# Altium schematic sheet CompareVoltage.SchDoc(CV)
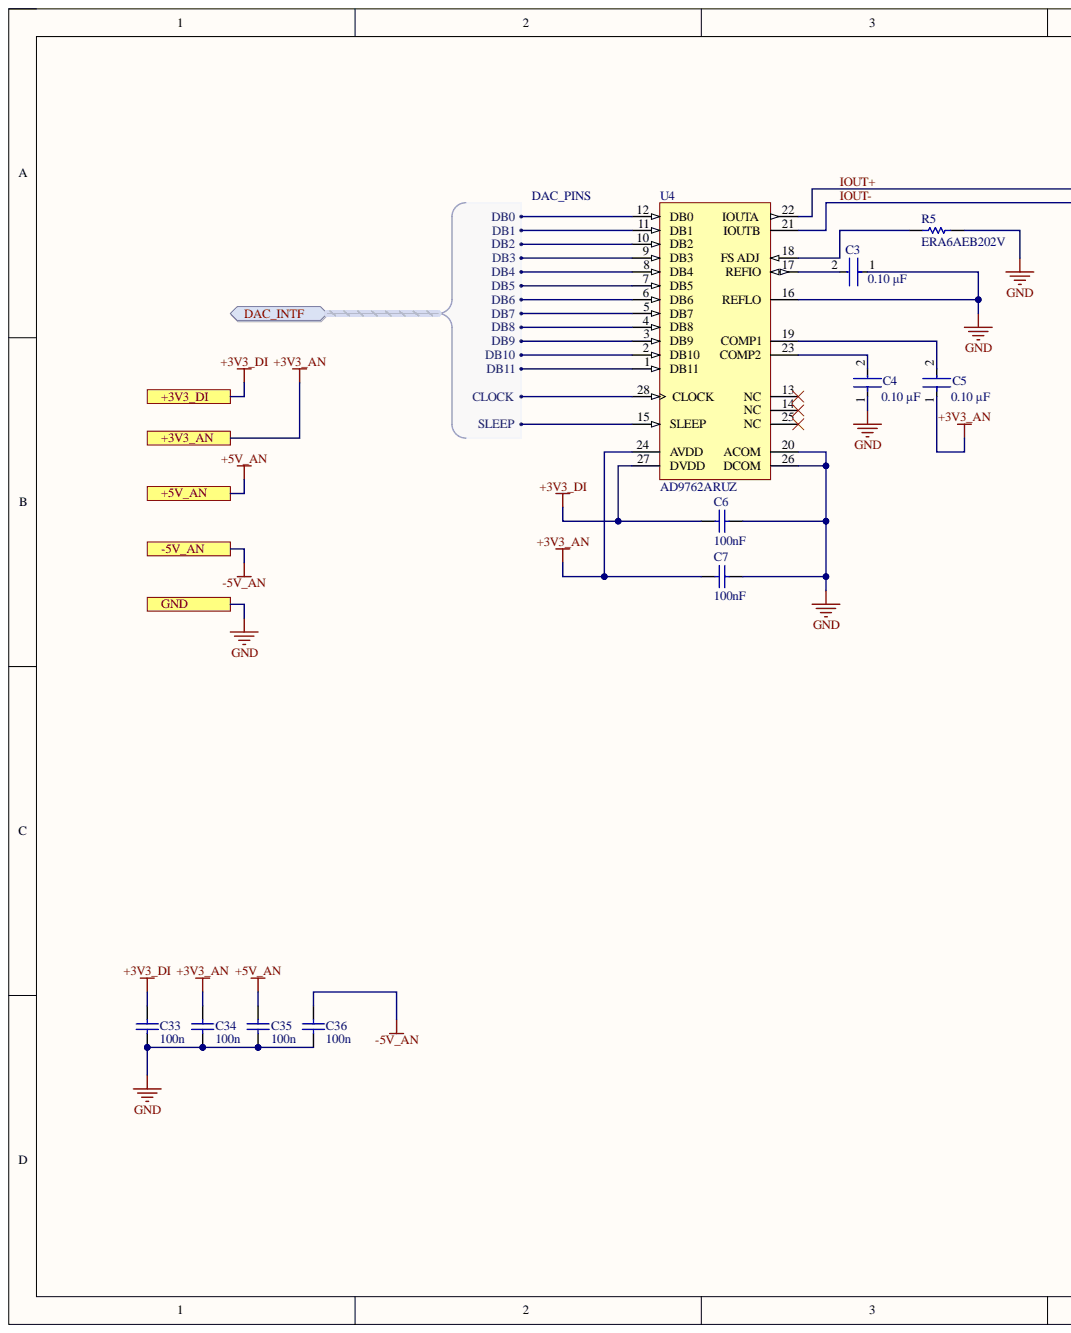
[diagram p0: CompareVoltage.SchDoc, left]
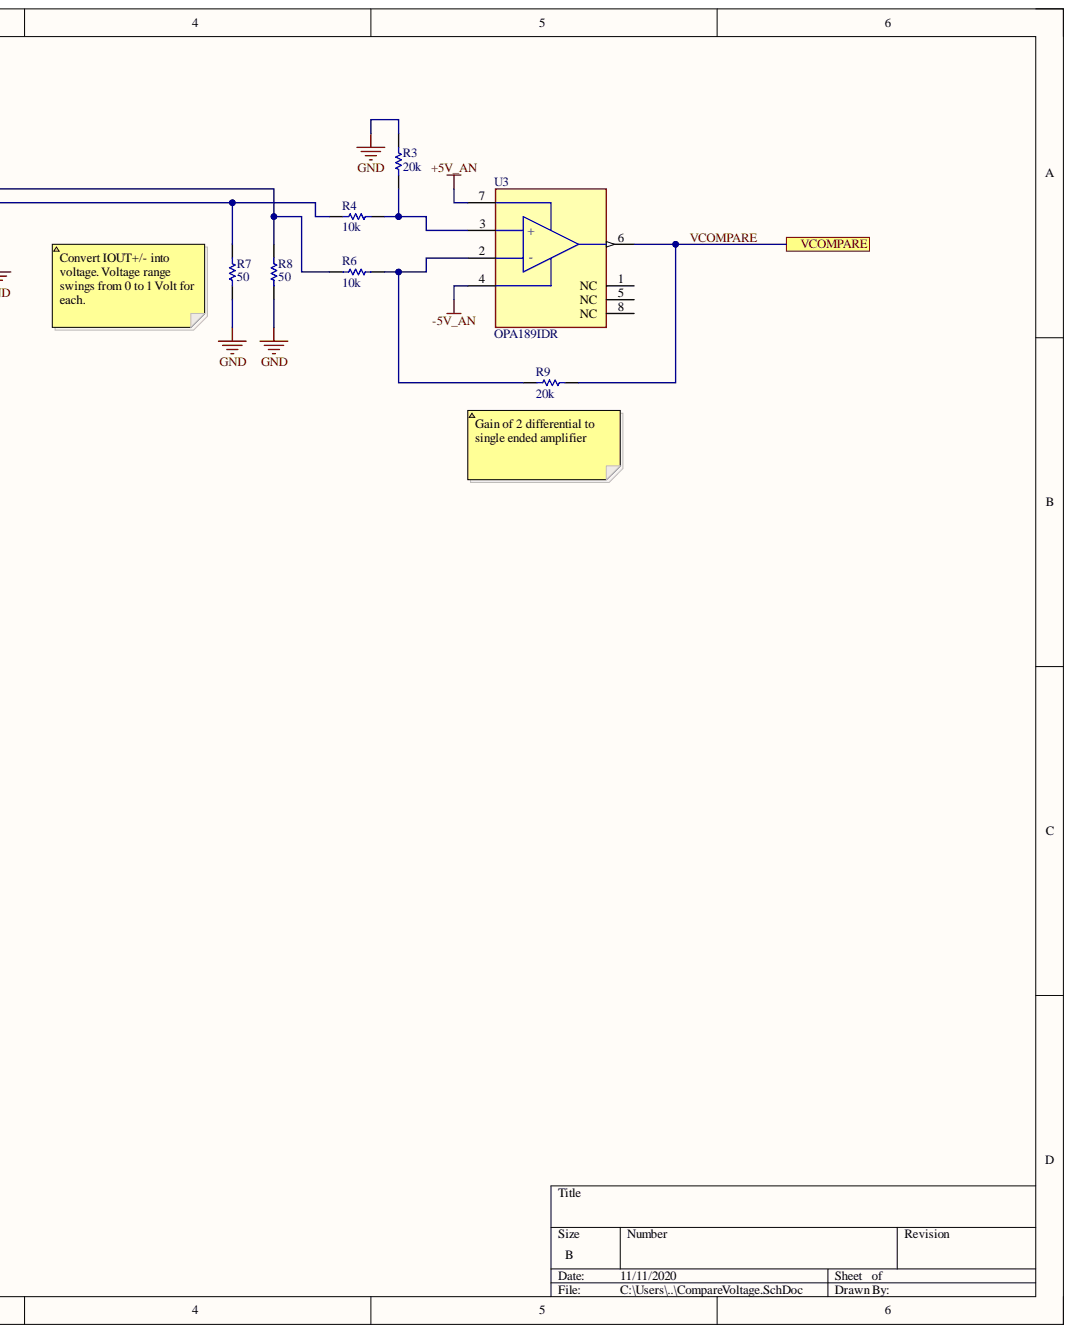
[diagram p1: CompareVoltage.SchDoc, right]

## Parts
C3  value 0.10 μF  Capacitance: 0.10 μF  [FP-C1206C-EB-MFG]  (hidden comment: C1206C104J3GACTU)
C4  value 0.10 μF  [FP-C1206C-EB-MFG]  (hidden comment: C1206C104J3GACTU)
C5  value 0.10 μF  Capacitance: 0.10 μF  [FP-C1206C-EB-MFG]  (hidden comment: C1206C104J3GACTU)
C6  Capacitance: 100nF  [CAPC1608X90X40ML10T20]  (hidden comment: CC0603KRX7R9BB104)
C7  Capacitance: 100nF  [CAPC1608X90X40ML10T20]  (hidden comment: CC0603KRX7R9BB104)
C33  value 100n  [CAPC2013X88X50NL20T25]  (hidden comment: C0805C104K5RACTU)
C34  value 100n  [CAPC2013X88X50NL20T25]  (hidden comment: C0805C104K5RACTU)
C35  value 100n  [CAPC2013X88X50NL20T25]  (hidden comment: C0805C104K5RACTU)
C36  value 100n  [CAPC2013X88X50NL20T25]  (hidden comment: C0805C104K5RACTU)
R3  20k  [RESC1609X50X30LL10T20]
R4  10k  [RESC1609X50X30NL8T20]
R5  ERA6AEB202V  [RESC2013X60X40ML20T25]
R6  10k  [RESC1609X50X30NL8T20]
R7  CRCW060350R0FKEA  [RESC1609X50X30NL10T20]  (value not drawn)
R8  CRCW060350R0FKEA  [RESC1609X50X30NL10T20]  (value not drawn)
R9  20k  [RESC1609X50X30LL10T20]
U3  OPA189IDR  [FP-D0008A-MFG]
U4  AD9762ARUZ  [RU-28_L]

## Ports
+3V3_AN, +3V3_DI, +5V_AN, -5V_AN, DAC_INTF, DAC_INTF.CLOCK, DAC_INTF.DB0, DAC_INTF.DB1, DAC_INTF.DB2, DAC_INTF.DB3, DAC_INTF.DB4, DAC_INTF.DB5, DAC_INTF.DB6, DAC_INTF.DB7, DAC_INTF.DB8, DAC_INTF.DB9, DAC_INTF.DB10, DAC_INTF.DB11, DAC_INTF.SLEEP, GND, VCOMPARE

## Nets
+3V3_AN: C5.1 C7.2 C34.2 U4.24(AVDD) ; port +3V3_AN
+3V3_DI: C6.2 C33.2 U4.27(DVDD) ; port +3V3_DI
+5V_AN: C35.2 U3.7 ; port +5V_AN
-5V_AN: C36.2 U3.4 ; port -5V_AN
GND: C3.1 C4.1 C6.1 C7.1 C33.1 C34.1 C35.1 C36.1 R3.2 R5.2 R7.1 R8.1 U4.16(REFLO) U4.20(ACOM) U4.26(DCOM) ; port GND
IOUT+: R6.1 R8.2 U4.22(IOUTA)
IOUT-: R4.1 R7.2 U4.21(IOUTB)
VCOMPARE: R9.2 U3.6 ; port VCOMPARE
NetC3_2: C3.2 U4.17(REFIO)
NetC4_2: C4.2 U4.23(COMP2)
NetC5_2: C5.2 U4.19(COMP1)
NetR3_1: R3.1 R4.2 U3.3
NetR5_1: R5.1 U4.18(FS_ADJ)
NetR6_2: R6.2 R9.1 U3.2
NetU3_1: U3.1(NC)
NetU3_5: U3.5(NC)
NetU3_8: U3.8(NC)
NetU4_1: U4.1(DB11) ; port DAC_INTF
NetU4_2: U4.2(DB10) ; port DAC_INTF
NetU4_3: U4.3(DB9) ; port DAC_INTF
NetU4_4: U4.4(DB8) ; port DAC_INTF
NetU4_5: U4.5(DB7) ; port DAC_INTF
NetU4_6: U4.6(DB6) ; port DAC_INTF
NetU4_7: U4.7(DB5) ; port DAC_INTF
NetU4_8: U4.8(DB4) ; port DAC_INTF
NetU4_9: U4.9(DB3) ; port DAC_INTF
NetU4_10: U4.10(DB2) ; port DAC_INTF
NetU4_11: U4.11(DB1) ; port DAC_INTF
NetU4_12: U4.12(DB0) ; port DAC_INTF
NetU4_13: U4.13(NC)
NetU4_14: U4.14(NC)
NetU4_15: U4.15(SLEEP) ; port DAC_INTF
NetU4_25: U4.25(NC)
NetU4_28: U4.28(CLOCK) ; port DAC_INTF

## Library data of the parts
C3 C5 = CMP-03020-000180-1: CAP CER 0.1UF 25V 5% NP0 1206; Manufacturer: KEMET; Automotive Grade: Grade 0; Material: Ceramic; Temperature Characteristic: C0G; Temperature Coefficient: 15%; Tolerance: 0.05; Automotive: Yes; Category: Cap; Component Kind: Standard; ComponentLink2Description: Datasheet; ComponentLink2URL: https://content.kemet.com/datasheets/KEM_C1007_X8R_ULTRA_150C_SMD.pdf; Device Class L1: Passive Components; Device Class L2: Capacitors; Device Class L3: Ceramic Capacitors; Digikey Description: CAP CER 0.1UF 25V 5% NP0 1206; Height: 0.88mm; Ipc Land Pattern Name: CAPC320160X78; Lead Free: Yes; Library Name: Altium Content Vault; Manufacturer Part Number: C1206C104J3GACTU; Mouser Description: Multilayer Ceramic Capacitors MLCC - SMD/SMT 25volts 0.1uF 5% C0G; Octopart: https://octopart.com/c1206c104j3gactu-kemet-9145095; Package: C1206C-EB; RoHS: TRUE; Temperature: -55°C to +150°C; Temperature Range High: +150°C; Temperature Range Low: -55°C; Voltage Rating: 25 V
C4 = CMP-03020-000180-1: CAP CER 0.1UF 25V 5% NP0 1206; Manufacturer: KEMET; Automotive Grade: Grade 0; Capacitance: 0.10 μF; Material: Ceramic; Temperature Characteristic: C0G; Temperature Coefficient: 15%; Tolerance: 0.05; Value: 0.10 μF; Automotive: Yes; Category: Cap; Component Kind: Standard; ComponentLink2Description: Datasheet; ComponentLink2URL: https://content.kemet.com/datasheets/KEM_C1007_X8R_ULTRA_150C_SMD.pdf; Device Class L1: Passive Components; Device Class L2: Capacitors; Device Class L3: Ceramic Capacitors; Digikey Description: CAP CER 0.1UF 25V 5% NP0 1206; Height: 0.88mm; Ipc Land Pattern Name: CAPC320160X78; Lead Free: Yes; Library Name: Altium Content Vault; Manufacturer Part Number: C1206C104J3GACTU; Mouser Description: Multilayer Ceramic Capacitors MLCC - SMD/SMT 25volts 0.1uF 5% C0G; Octopart: https://octopart.com/c1206c104j3gactu-kemet-9145095; Package: C1206C-EB; RoHS: TRUE; Temperature: -55°C to +150°C; Temperature Range High: +150°C; Temperature Range Low: -55°C; Voltage Rating: 25 V
C6 C7 = CMP-2100-03601-1: Chip Capacitor, 100 nF, +/- 10%, 50 V, -55 to 125 degC, 0603 (1608 Metric), RoHS, Tape and Reel; Manufacturer: Yageo; Dielectric: X7R; Dielectric Material: Ceramic; Voltage: 50V; Case Code (Imperial): 0603; Case Code (Metric): 1608; Case/Package: 0603; Component Kind: Standard; Datasheet URL: http://www.yageo.com/documents/recent/UPY-GPHC_X7R_6.3V-to-50V_15.pdf; Datasheet Version: Rev. 15, 10/2016; Depth: 0.8mm; Height: 0.8mm; Lead Free: LeadFree; Length: 1.6mm; Library Name: Altium Content Vault; Manufacturer URL: http://www.yageo.com/; Max Operating Temperature: 125degC; Min Operating Temperature: -55degC; Number of Pins: 2; Package Description: 2-Pin Surface Mount Device, Body 1.6 x 0.8 mm; Package Quantity: 1; Package Reference: 0603; Packaging: TapeandReel; Radiation Hardening: No; REACH SVHC: NoSVHC; RoHSCompliant: True; Termination: SMD/SMT; Thickness: 0.035inch; Tolerance: 10%; Voltage Rating: 50V; Width: 0.031inch
C33-C36 = CMP-2007-00279-1: Manufacturer: Kemet; Component Kind: Standard; Library Name: Altium Content Vault; Part Number: C0805C104K5RACTU
R3 R9 = CMP-2000-03068-1: Manufacturer: Vishay Dale; Part Number: CRCW060320K0FKEA; Component Kind: Standard; Library Name: Altium Content Vault
R4 R6 = CMP-1666-00005-4: Chip Resistor, 10 KOhm, +/- 1%, 100 mW, -55 to 155 degC, 0603 (1608 Metric), RoHS, Tape and Reel; Manufacturer: Vishay Semiconductor; Voltage Rating: 75 V; Component Kind: Standard; ComponentLink1Description: Manufacturer URL; ComponentLink1URL: http://www.vishay.com/; ComponentLink2Description: Datasheet; ComponentLink2URL: https://datasheet.ciiva.com/11809/0900766b80d98afc-11809037.pdf; DatasheetVersion: 06/2012; Height: 0.45 mm; Length: 1.55 mm; Library Name: Altium Content Vault; Max Operating Temperature: 155 degC; Min Operating Temperature: -55 degC; Mounting Technology: Surface Mount; Package Quantity: 5000; PackageDescription: 2-Pin Surface Mount Device, Body 1.575 x 0.85 mm; PackageReference: 0603; Packaging: Tape and Reel; REACH SVHC: Unknown; RoHSCompliant: true
R5 = CMP-2001-00609-1: Manufacturer: Panasonic; Component Kind: Standard; Library Name: Altium Content Vault; Part Number: ERA6AEB202V
R7 R8 = CMP-2000-03436-1: Manufacturer: Vishay Dale; Resistance: 50; Component Kind: Standard; Library Name: Altium Content Vault; Part Number: CRCW060350R0FKEA
U3 = CMP-04895-000042-1: 36V ZERO DRIFT OP-AMP; Manufacturer: Texas Instruments; Gain Bandwidth: 14MHz; Input Bias Current: 0.00007uA; Input Offset Voltage: 0.0004mV; Max Dual Supply Voltage: 18V; Max Junction Temp: +150°C; Min Dual Supply Voltage: 2.25V; Min Supply Voltage: 4.5V; Nominal Supply Current: 1.3mA; Number Of Channels: 1; Output Current Per Channel: 65mA; Standoff Height: 0.11mm; Voltage Gain: 170dB; Ambient Temperature Range High: +125°C; Ambient Temperature Range Low: -40°C; Automotive: No; Category: IC; Component Kind: Standard; ComponentLink2Description: Datasheet; ComponentLink2URL: http://www.ti.com/lit/ds/symlink/opa189.pdf; Device Class L1: Integrated Circuits (ICs); Device Class L2: Linear ICs; Device Class L3: Amplifiers - Op Amps; Digikey Description: 36V ZERO DRIFT OP-AMP; Height: 1.75mm; Ipc Land Pattern Name: SOIC127P600X175-8; Lead Free: Yes; Library Name: Altium Content Vault; Manufacturer Part Number: OPA189IDR; Max Supply Voltage: 36V; Mouser Description: Op Amp Single Zero Drift Amplifier R-R O/P ±18V/36V 8-Pin SOIC T/R; Octopart: https://octopart.com/opa189idr-texas+instruments-84619764; Package: D0008A; RoHS: TRUE; Temperature: -40°C to +125°C; Temperature Range High: +125°C; Temperature Range Low: -40°C
U4 = CMP-0318-01862-2: Single 12-Bit TxDAC, 125 Msps, -40 to +85 degC, RU-28, Pb-Free, Tube; Generic Part Number: AD9762; Manufacturer: Analog Devices; # DAC Outputs: 1; Max V+: 5.5V; Op Pwr Diss: 160mW; Resolution (Bits): 12; Settling Time: 35ns; Single Supply: Yes; Code_JEDEC: MO-153-AE; Component Kind: Standard; ComponentLink1Description: Manufacturer URL; ComponentLink1URL: http://www.analog.com/en/index.html; ComponentLink2Description: Datasheet; ComponentLink2URL: http://www.analog.com/static/imported-files/data_sheets/AD9762.pdf; ComponentLink3Description: Package Specification; ComponentLink3URL: http://www.analog.com/static/imported-files/packages/249854663RU_28.pdf; ComponentLink4Description: Product URL; ComponentLink4URL: http://www.analog.com/en/digital-to-analog-converters/da-converters/ad9762/products/product.html; DatasheetVersion: Rev.B; Library Name: Altium Content Vault; Mounting Technology: Surface Mount; PackageDescription: 28-Lead Thin Shrink Small Outline Package [TSSOP]; PackageReference: RU-28; PackageVersion: 2005; Packing: Tube; PartNumber: AD9762ARUZ; RevisionNote: Component URL fixed; RoHS: Y
